# Altium schematic sheet 18_ATK_RK3588S_GMAC.SchDoc
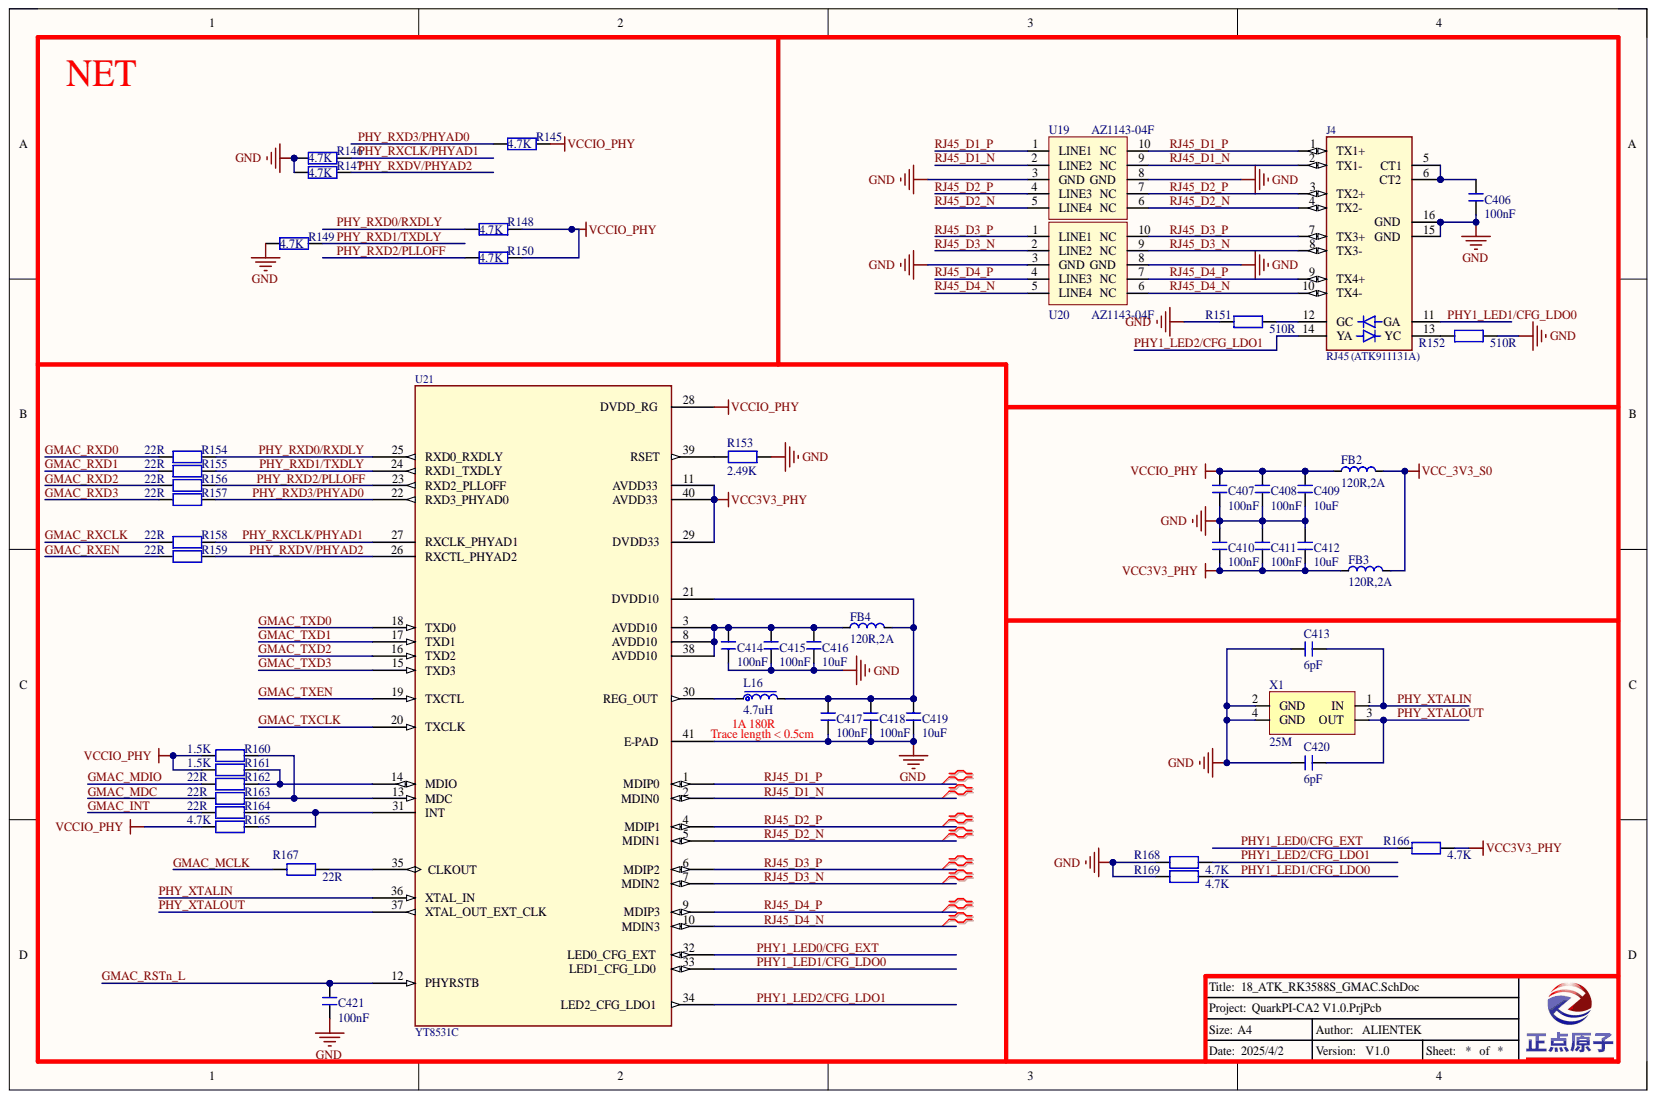
[diagram p0: 18_ATK_RK3588S_GMAC.SchDoc]

## Parts
C406  100nF  [C 0402]
C407  100nF  [C 0201]
C408  100nF  [C 0201]
C409  10uF  [C 0402]
C410  100nF  [C 0201]
C411  100nF  [C 0201]
C412  10uF  [C 0402]
C413  6pF  [C 0402]
C414  100nF  [C 0201]
C415  100nF  [C 0201]
C416  10uF  [C 0402]
C417  100nF  [C 0201]
C418  100nF  [C 0201]
C419  10uF  [C 0402]
C420  6pF  [C 0402]
C421  100nF  [C 0201]
FB2  120R,2A  [L 0603]
FB3  120R,2A  [L 0603]
FB4  120R,2A  [L 0603]
J4  RJ45 (ATK911131A)  [HR911131A]
L16  4.7uH  [L-2520]
R145  4.7K  [R 0201]
R146  4.7K  [R 0201]
R147  4.7K  [R 0201]
R148  4.7K  [R 0201]
R149  4.7K  [R 0201]
R150  4.7K  [R 0201]
R151  510R  [R 0402]
R152  510R  [R 0402]
R153  2.49K  [R 0402]
R154  22R  [R 0201]
R155  22R  [R 0201]
R156  22R  [R 0201]
R157  22R  [R 0201]
R158  22R  [R 0201]
R159  22R  [R 0201]
R160  1.5K  [R 0201]
R161  1.5K  [R 0201]
R162  22R  [R 0201]
R163  22R  [R 0201]
R164  22R  [R 0201]
R165  4.7K  [R 0201]
R166  4.7K  [R 0402]
R167  22R  [R 0201]
R168  4.7K  [R 0402]
R169  4.7K  [R 0402]
U19  AZ1143-04F  [DFN2510P10E]
U20  AZ1143-04F  [DFN2510P10E]
U21  YT8531C  [QFN40]
X1  25M  [XTAL-3225]

## Nets
GND: C406.2 C407.2 C408.2 C409.2 C410.1 C411.1 C412.1 C413.1 C414.2 C415.2 C416.2 C417.2 C418.2 C419.2 C420.1 C421.2 J4.15(GND) J4.16(GND) R146.1 R147.1 R149.1 R151.1 R152.2 R153.2 R168.1 R169.1 U19.3(GND) U19.8(GND) U20.3(GND) U20.8(GND) U21.41(E-PAD) X1.2(GND) X1.4(GND)
VCC3V3_PHY: C410.2 C411.2 C412.2 FB3.1 R166.2 U21.11(AVDD33) U21.29(DVDD33) U21.40(AVDD33)
VCC_3V3_S0: FB2.2 FB3.2
VCCIO_PHY: C407.1 C408.1 C409.1 FB2.1 R145.2 R148.2 R150.2 R160.1 R161.1 R165.1 U21.28(DVDD_RG)
GMAC_INT: R164.1
GMAC_MCLK: R167.1
GMAC_MDC: R163.1
GMAC_MDIO: R162.1
GMAC_RSTn_L: C421.1 U21.12(PHYRSTB)
GMAC_RXCLK: R158.1
GMAC_RXD0: R154.1
GMAC_RXD1: R155.1
GMAC_RXD2: R156.1
GMAC_RXD3: R157.1
GMAC_RXEN: R159.1
GMAC_TXCLK: U21.20(TXCLK)
GMAC_TXD0: U21.18(TXD0)
GMAC_TXD1: U21.17(TXD1)
GMAC_TXD2: U21.16(TXD2)
GMAC_TXD3: U21.15(TXD3)
GMAC_TXEN: U21.19(TXCTL)
PHY1_LED0/CFG_EXT: R166.1 U21.32(LED0_CFG_EXT)
PHY1_LED1/CFG_LDO0: J4.11(GA) R169.2 U21.33(LED1_CFG_LD0)
PHY1_LED2/CFG_LDO1: J4.14(YA) R168.2 U21.34(LED2_CFG_LDO1)
PHY_RXCLK/PHYAD1: R146.2 R158.2 U21.27(RXCLK_PHYAD1)
PHY_RXD0/RXDLY: R148.1 R154.2 U21.25(RXD0_RXDLY)
PHY_RXD1/TXDLY: R149.2 R155.2 U21.24(RXD1_TXDLY)
PHY_RXD2/PLLOFF: R150.1 R156.2 U21.23(RXD2_PLLOFF)
PHY_RXD3/PHYAD0: R145.1 R157.2 U21.22(RXD3_PHYAD0)
PHY_RXDV/PHYAD2: R147.2 R159.2 U21.26(RXCTL_PHYAD2)
PHY_XTALIN: C413.2 U21.36(XTAL_IN) X1.1(IN)
PHY_XTALOUT: C420.2 U21.37(XTAL_OUT_EXT_CLK) X1.3(OUT)
RJ45_D1_N: J4.2(TX1-) U19.2(LINE2) U19.9(NC) U21.2(MDIN0)
RJ45_D1_P: J4.1(TX1+) U19.1(LINE1) U19.10(NC) U21.1(MDIP0)
RJ45_D2_N: J4.4(TX2-) U19.5(LINE4) U19.6(NC) U21.5(MDIN1)
RJ45_D2_P: J4.3(TX2+) U19.4(LINE3) U19.7(NC) U21.4(MDIP1)
RJ45_D3_N: J4.8(TX3-) U20.2(LINE2) U20.9(NC) U21.7(MDIN2)
RJ45_D3_P: J4.7(TX3+) U20.1(LINE1) U20.10(NC) U21.6(MDIP2)
RJ45_D4_N: J4.10(TX4-) U20.5(LINE4) U20.6(NC) U21.10(MDIN3)
RJ45_D4_P: J4.9(TX4+) U20.4(LINE3) U20.7(NC) U21.9(MDIP3)
NetC406_1: C406.1 J4.5(CT1) J4.6(CT2)
NetC414_1: C414.1 C415.1 C416.1 FB4.1 U21.3(AVDD10) U21.8(AVDD10) U21.38(AVDD10)
NetC417_1: C417.1 C418.1 C419.1 FB4.2 L16.2 U21.21(DVDD10)
NetJ4_12: J4.12(GC) R151.2
NetJ4_13: J4.13(YC) R152.1
NetL16_1: L16.1 U21.30(REG_OUT)
NetR153_1: R153.1 U21.39(RSET)
NetR160_2: R160.2 R163.2 U21.13(MDC)
NetR161_2: R161.2 R162.2 U21.14(MDIO)
NetR164_2: R164.2 R165.2 U21.31(INT)
NetR167_2: R167.2 U21.35(CLKOUT)

## Library data of the parts
C406-C421 = C: 无极性电容; BOM名称: 无极性贴片电容; 版权归属: 正点原子; Component Kind: Standard; Library Name: 正点原子封装库V1.0.IntLib; 学习资源: www.openedv.com
FB2-FB4 = FB: 贴片磁珠; BOM名称: 小功率贴片电感; Parameter: 电感/磁珠; 版权归属: 正点原子; Component Kind: Standard; Library Name: 正点原子封装库V1.0.IntLib; 学习资源: www.openedv.com
J4 = HR911131A/C: 千兆以太网接口; Component Kind: Standard; Library Name: ATK-DLRK3588B V0.2.IntLib
L16 = L: 电感; BOM名称: 功率屏蔽电感; Parameter: 电感/磁珠; 版权归属: 正点原子; Component Kind: Standard; Library Name: 正点原子封装库V1.0.IntLib; 学习资源: www.openedv.com
R145-R169 = R: 电阻; BOM名称: 贴片电阻; 版权归属: 正点原子; Component Kind: Standard; Library Name: 正点原子封装库V1.0.IntLib; Parameter: 电阻; 学习资源: www.openedv.com
U19 U20 = AZ1143-04F: ESD保护二极管阵列; Component Kind: Standard; Library Name: ATK-DLRK3588B V0.2.IntLib
U21 = RTL8211F-CG: Component Kind: Standard; Library Name: ATK-DLRK3588B V0.2.IntLib
X1 = XTAL-4P: 4脚无源晶振; BOM名称: 4脚晶振; Parameter: 晶振; 版权归属: 正点原子; Component Kind: Standard; Library Name: 正点原子封装库V1.0.IntLib; 学习资源: www.openedv.com
